# Altium schematic sheet Photodiode_board_schematic.SchDoc
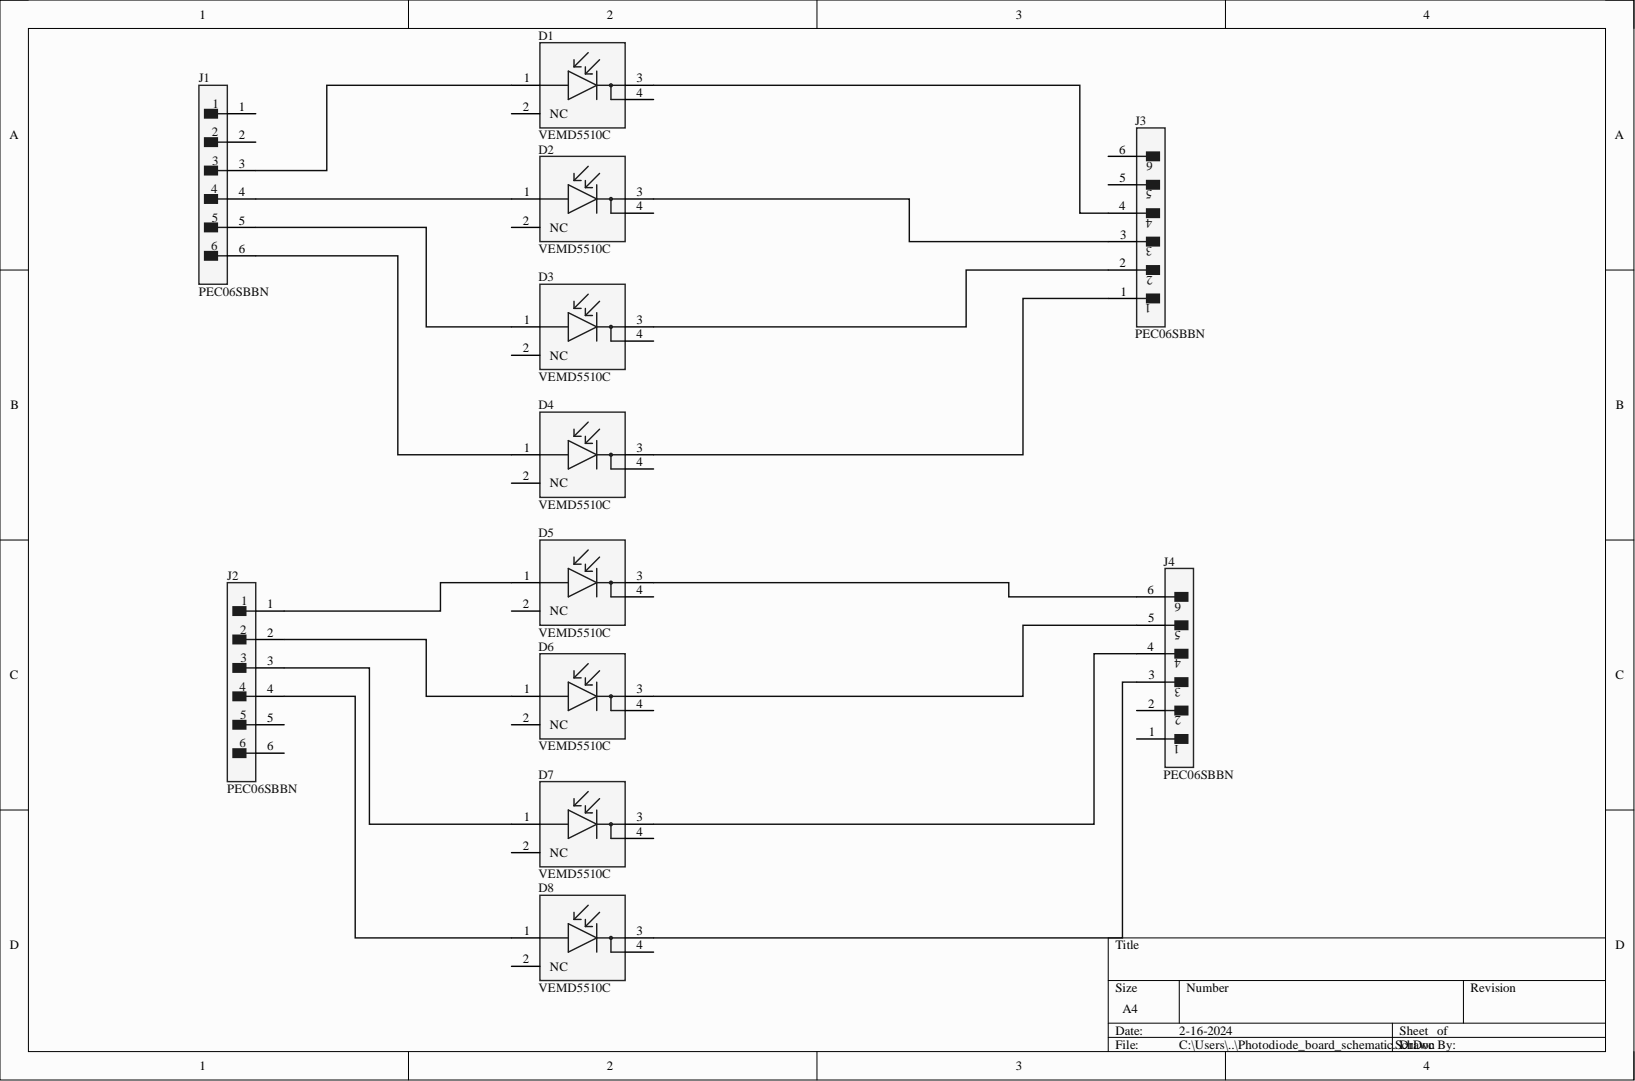
[diagram p0: Photodiode_board_schematic.SchDoc]

## Parts
D1  VEMD5510C  [FP-6_550-5329_01-4-MFG]
D2  VEMD5510C  [FP-6_550-5329_01-4-MFG]
D3  VEMD5510C  [FP-6_550-5329_01-4-MFG]
D4  VEMD5510C  [FP-6_550-5329_01-4-MFG]
D5  VEMD5510C  [FP-6_550-5329_01-4-MFG]
D6  VEMD5510C  [FP-6_550-5329_01-4-MFG]
D7  VEMD5510C  [FP-6_550-5329_01-4-MFG]
D8  VEMD5510C  [FP-6_550-5329_01-4-MFG]
J1  PEC06SBBN  [FP-PEC06SBBN-MFG]
J2  PEC06SBBN  [FP-PEC06SBBN-MFG]
J3  PEC06SBBN  [FP-PEC06SBBN-MFG]
J4  PEC06SBBN  [FP-PEC06SBBN-MFG]

## Nets
NetD1_1: D1.1 J1.3
NetD1_3: D1.3 J3.4
NetD2_1: D2.1 J1.4
NetD2_3: D2.3 J3.3
NetD3_1: D3.1 J1.5
NetD3_3: D3.3 J3.2
NetD4_1: D4.1 J1.6
NetD4_3: D4.3 J3.1
NetD5_1: D5.1 J2.1
NetD5_3: D5.3 J4.6
NetD6_1: D6.1 J2.2
NetD6_3: D6.3 J4.5
NetD7_1: D7.1 J2.3
NetD7_3: D7.3 J4.4
NetD8_1: D8.1 J2.4
NetD8_3: D8.3 J4.3

Not connected: D1 2(NC) 4; D2 2(NC) 4; D3 2(NC) 4; D4 2(NC) 4; D5 2(NC) 4; D6 2(NC) 4; D7 2(NC) 4; D8 2(NC) 4; J1 1 2; J2 5 6; J3 5 6; J4 1 2

## Library data of the parts
D1-D8 = CMP-02531-000009-1: PHOTODIODE 440 TO 700 NM; Dark Current: 200pA; Diode Type: PIN; Response Time: 70ns; Viewing Angle: 130°; Wavelength: 550nm; Case/Package: SMD/SMT; Component Kind: Standard; Library Name: Altium Content Vault; Max Operating Temperature: 100°C; Min Operating Temperature: -40°C; Mount: Surface Mount; Packaging: Cut Tape
J1-J4 = CMP-25680-000045-1: CONN HEADER R/A 6POS 2.54MM; Connector Type: Male Pin; Gender: Male; Number of Contacts: 6; Pitch: 0.1inch; Color: Black; Component Kind: Standard; Contact Material: Bronze; Contact Mating Length: 0.23inch; Contact Plating: Tin; Contact Type: Male Pin; Height: 3.56mm; Housing Color: Black; Housing Material: Plastic; Lead Free: Lead Free; Length: 15.2mm; Library Name: Altium Content Vault; Mount: Through Hole; Number of Positions: 6; Number of Rows: 1; Package Quantity: 1; Packaging: Bulk; RoHS Compliant: Yes; Termination: Solder; Width: 2.54mm
